# Altium schematic sheet Peripherals.SchDoc(Peripherals)
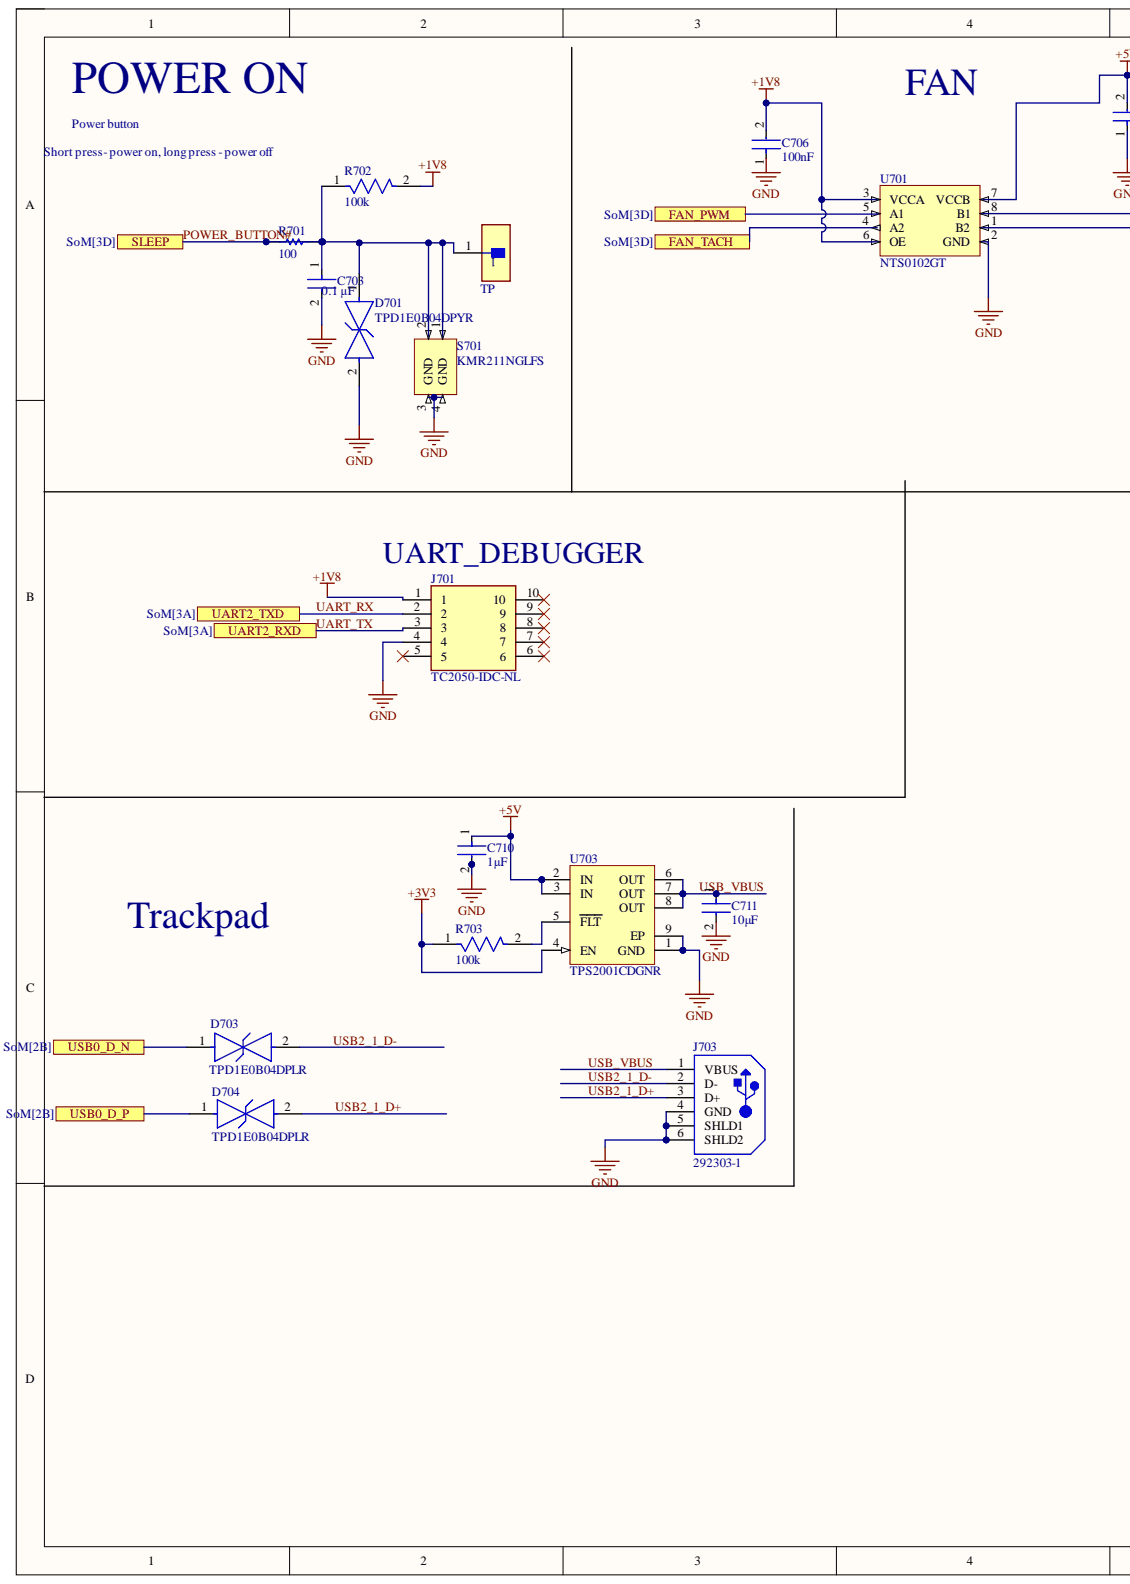
[diagram p0: Peripherals.SchDoc, left]
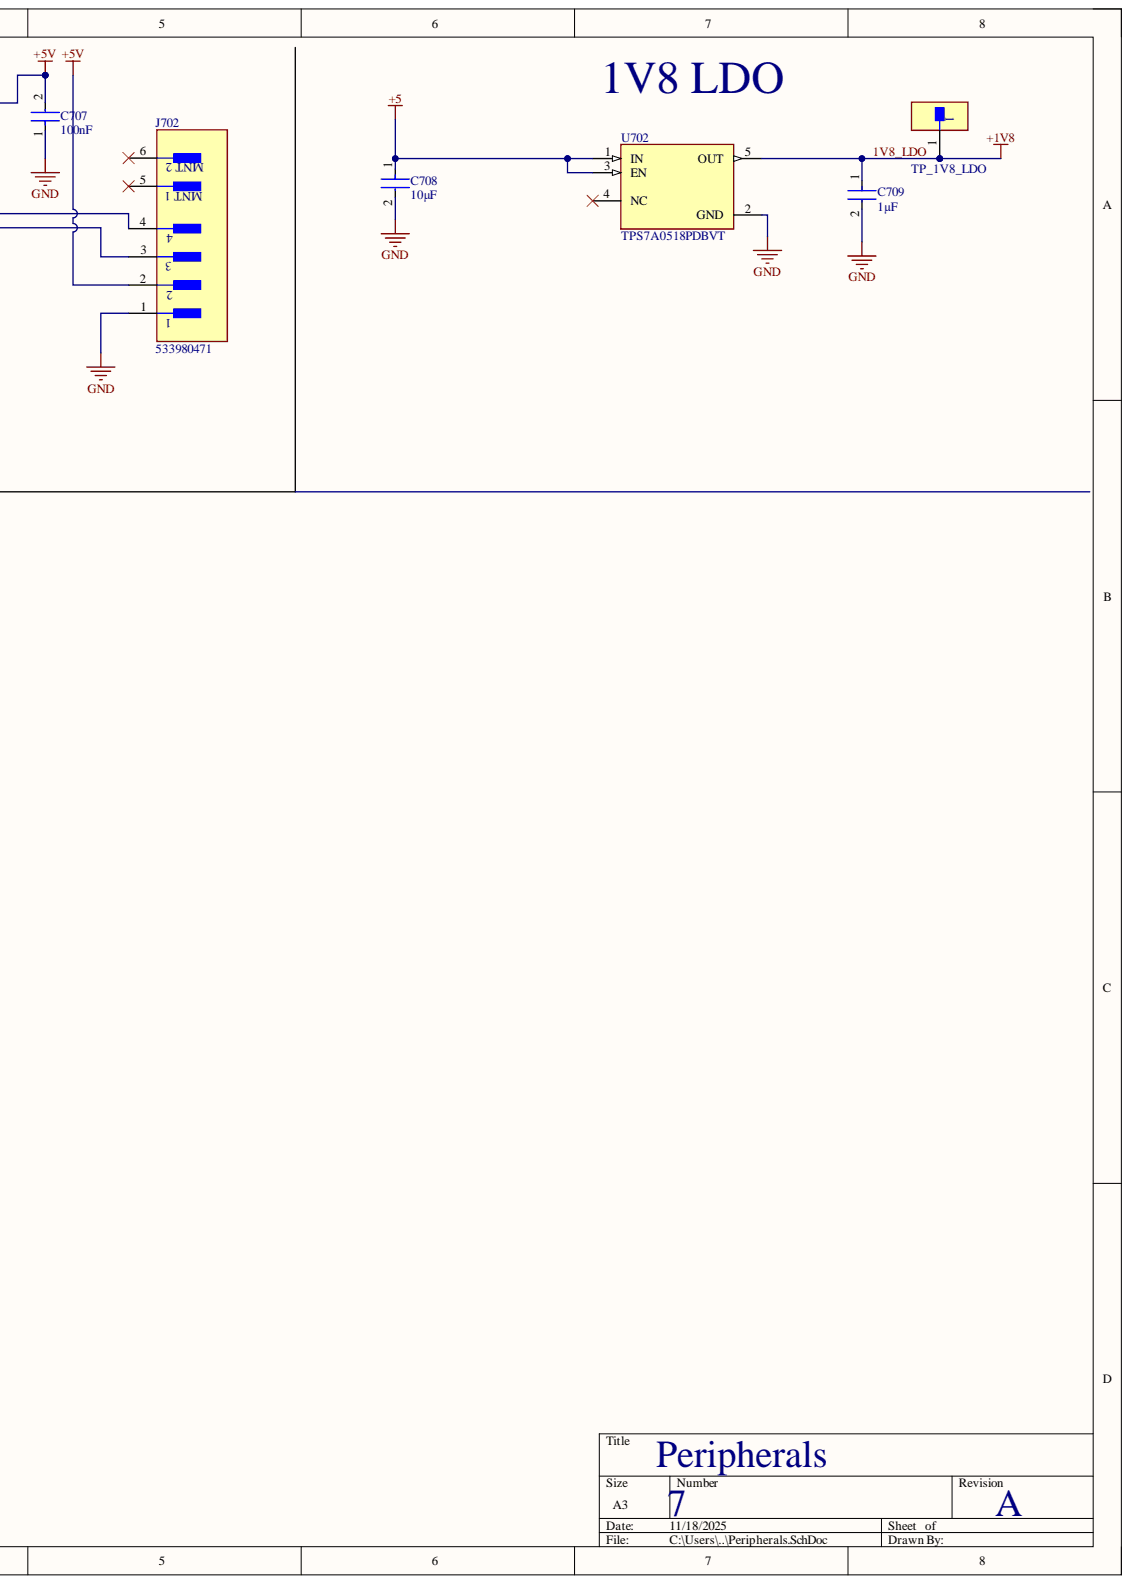
[diagram p1: Peripherals.SchDoc, right]

## Parts
C703  0.1 µF  [FP-GRM155-0_05-IPC_B]
C706  100nF  [FP-GRM155-0_05-IPC_B]
C707  100nF  [FP-GRM155-0_05-IPC_B]
C708  10μF  [FP-GRM31C-0_2-e0_3_0_8-MFG]
C709  1µF  [FP-GRM15-0_1-MFG]
C710  1µF  [FP-GRM15-0_1-MFG]
C711  10μF  [FP-GRM31C-0_2-e0_3_0_8-MFG]
D701  TPD1E0B04DPYR  [FP-DPY0002A-MFG]
D703  TPD1E0B04DPLR  [FP-DPL0002A-MFG]
D704  TPD1E0B04DPLR  [FP-DPL0002A-MFG]
J701  TC2050-IDC-NL  [TAG-CONNECT_TC2050-IDC-NL]
J702  533980471  [FP-533980471-MFG]
J703  292303-1  [TE-292303-1_V]
R701  100  [RESC1005X40X25ML05T10]
R702  100k  [FP-RC0402-0_4-IPC_A]
R703  100k  [FP-RC0402-0_4-IPC_A]
S701  KMR211NGLFS  [SW_KMR211NGLFS]
TP700  TP  [FP-S2751-46R-MFG]
TP701  TP_1V8_LDO  [FP-S2751-46R-MFG]
U701  NTS0102GT  [PSON50P100X195X50-8N]
U702  TPS7A0518PDBVT  [SOT95P280X145-5N]
U703  TPS2001CDGNR  [DGN0008D_L]

## Ports
FAN_PWM, FAN_TACH, SLEEP, UART2_RXD, UART2_TXD, USB0_D_N, USB0_D_P

## Nets
+3V3: R703.1 U703.4(EN)
+5: C708.1 U702.1(IN) U702.3(EN)
+5V: C707.2 C710.1 J702.2 U701.7(VCCB) U703.2(IN) U703.3(IN)
GND: C703.2 C706.1 C707.1 C708.2 C709.2 C710.2 C711.2 D701.2 J701.4 J702.1 J703.4(GND) J703.5(SHLD1) J703.6(SHLD2) S701.3(GND) S701.4(GND) U701.2(GND) U702.2(GND) U703.1(GND) U703.9(EP)
1V8_LDO: C706.2 C709.1 J701.1 R702.2 TP701.1 U701.3(VCCA) U701.6(OE) U702.5(OUT)
FAN_PWM: U701.5(A1) ; port FAN_PWM
FAN_TACH: U701.4(A2) ; port FAN_TACH
POWER_BUTTON#: C703.1 D701.1 R701.1 R701.2 R702.1 S701.1 S701.2 TP700.1 ; port SLEEP
UART_RX: J701.2 ; port UART2_TXD
UART_TX: J701.3 ; port UART2_RXD
USB0_D_N: D703.1 ; port USB0_D_N
USB0_D_P: D704.1 ; port USB0_D_P
USB2_1_D+: D704.2 J703.3(D+)
USB2_1_D-: D703.2 J703.2(D-)
USB_VBUS: C711.1 J703.1(VBUS) U703.6(OUT) U703.7(OUT) U703.8(OUT)
NetJ702_3: J702.3 U701.1(B2)
NetJ702_4: J702.4 U701.8(B1)
NetR703_2: R703.2 U703.5(FLT)

Not connected: J701 5 6 7 8 9 10; J702 5 6; U702 4(NC)

## Library data of the parts
C703 = CMP-06035-003750-1: Chip Multilayer Ceramic Capacitors for General Purpose, 0402, 0.10uF, X7R, 15%, 10%, 50V; Capacitance: 100nF; Case Code (Imperial): 0402; Case Code (Metric): 1005; Case/Package: 0402; Component Kind: Standard; Dielectric: X7R; Height: 0.5mm; Lead Free: Lead Free; Length: 0.039inch; Library Name: Altium Content Vault; Max Operating Temperature: 125°C; Min Operating Temperature: -55°C; Mount: Surface Mount; Package Quantity: 50000; Packaging: Tape and Reel; Resistor Type: Ceramic; Termination: SMD/SMT; Thickness: 0.022inch; Tolerance: 10%; Voltage Rating: 50V; Voltage Rating (DC): 50V; Weight: 0.000212oz; Width: 0.5mm
C706 C707 = CMP-2008-04639-2: Chip Multilayer Ceramic Capacitors for General Purpose, 0402, 0.10uF, X7R, 15%, 10%, 50V; Dissipation Factor: 0.025%; Capacitance: 100nF; Case Code (Imperial): 0402; Case Code (Metric): 1005; Case/Package: 0402; Component Kind: Standard; Depth: 0.5mm; Dielectric: X7R; Dielectric Material: Ceramic; Height: 0.5mm; Lead Free: Lead Free; Length: 1mm; Library Name: Altium Content Vault; Material: Ceramic; Max Operating Temperature: 125°C; Min Operating Temperature: -55°C; Mount: Surface Mount; Package Quantity: 10000; Packaging: Tape and Reel; REACH SVHC: No SVHC; Resistor Type: Ceramic; Termination: SMD/SMT; Thickness: 0.022inch; Tolerance: 10%; Voltage: 50V; Voltage Rating: 50V; Voltage Rating (DC): 50V; Weight: 0.000212oz; Width: 0.5mm
C708 C711 = CMP-06035-005515-1: Chip Multilayer Ceramic Capacitors for General Purpose, 1206, 10uF, X7R, 15%, 10%, 25V; Capacitance: 10uF; Case/Package: 1206; Component Kind: Standard; Dielectric: X7R; Lead Free: Lead Free; Length: 0.126inch; Library Name: Altium Content Vault; Max Operating Temperature: 125°C; Min Operating Temperature: -55°C; Mount: Surface Mount; Packaging: Tape and Reel; Thickness: 0.071inch; Tolerance: 10%; Voltage: 25V; Voltage Rating: 25V; Voltage Rating (DC): 25V; Width: 0.063inch
C709 C710 = CMP-2008-04556-2: CAP CER 1UF 10V X5R 0402; Manufacturer: Murata; Manufacturer Part Number: GRM155R61A105KE15D; Material: Ceramic; Temperature Coefficient: 15%; Tolerance: 10%; Automotive: No; Capacitance: 1uF; Category: Cap; Component Kind: Standard; ComponentLink2Description: Datasheet; ComponentLink2URL: https://search.murata.co.jp/Ceramy/image/img/A01X/G101/ENG/GRM155R61A105KE15-01A.pdf; Device Class L1: Passive Components; Device Class L2: Capacitors; Device Class L3: Ceramic Capacitors; Digikey Description: CAP CER 1UF 10V X5R 0402; Height: 0.55mm; Ipc Land Pattern Name: CAPC10050X50; Lead Free: Yes; Library Name: Altium Content Vault; Mouser Description: 0402 1 uF 10 V ±10% Tolerance X5R Surface Mount Multilayer Ceramic Capacitor; Octopart: https://octopart.com/grm155r61a105ke15d-murata-197442; Package: GRM15-0.1; RoHS: TRUE; Temperature: -55°C to +85°C; Temperature Characteristic: X5R; Temperature Range High: +85°C; Temperature Range Low: -55°C; Value: 1uF; Voltage Rating: 10V
D701 = CMP-04989-000020-1: TVS DIODE 3.6V 19V 2X1SON; Interface: USB; Min Breakdown Voltage: 6.7V; Number of Bidirectional Channels: 1; Peak Pulse Current: 1.7A; Peak Pulse Power: 15W; Power Line Protection: No; Case Code (Imperial): 0402; Case Code (Metric): 1006; Case/Package: 0402; Clamping Voltage: 19V; Component Kind: Standard; Library Name: Altium Content Vault; Max Operating Temperature: 125°C; Min Operating Temperature: -40°C; Mount: Surface Mount; Number of Channels: 1; Packaging: Cut Tape; Resistor Type: Zener; Reverse Standoff Voltage: 3.6V; RoHS Compliant: Yes
D703 D704 = CMP-04989-000017-1: TVS DIODE 3.6V 10.1V 2X2SON; Interface: USB; Number of Channels: 1; Component Kind: Standard; Lead Free: Lead Free; Library Name: Altium Content Vault; Max Operating Temperature: 125°C; Min Operating Temperature: -40°C; RoHS Compliant: Yes
J701 = CMP-002-00074-1: Adapter Cbl Plug Of Nails 10PIN; Component Kind: Standard; Library Name: Evan
J702 = CMP-04776-000105-1: CONN HEADER SMD 4POS 1.25MM; Manufacturer: Molex; Conn Gender: Male; Conn Orientation: Vertical; Current Rating: 1A; Number Of Contacts: 4; Standoff Height: 0.05mm; Voltage Rating: 125V; Ambient Temperature Range High: +105°C; Ambient Temperature Range Low: -40°C; Automotive: No; Category: Conn; Component Kind: Standard; ComponentLink2Description: Datasheet; ComponentLink2URL: https://www.molex.com/pdm_docs/ps/PS-51021-024-001.pdf; Contact Material: Tin; Device Class L1: Connectors; Device Class L2: Headers and Wire Housings; Device Class L3: unset; Digikey Description: CONN HEADER SMD 4POS 1.25MM; Footprint Url: https://www.molex.com/pdm_docs/sd/533980471_sd.pdf; Height: 5.1mm; Lead Free: Yes; Library Name: Altium Content Vault; Manufacturer Part Number: 533980471; Number Of Rows: 1; Octopart: https://octopart.com/53398-0471-molex-655296; Package: 0; Pitch: 1.25mm; RoHS: TRUE; Temperature: -40°C to +105°C; Temperature Range High: +105°C; Temperature Range Low: -40°C
J703 = CMP-2000-05404-1: 1 Port Right Angle Through Hole Mount Female Type A USB Connector, 4 Position, -55 to 85 degC, RoHS, Tube; Manufacturer: TE Connectivity; Connector Mounting: PCB; Connector Type: USBA; Contact Termination Type: Solder; Gender: Receptacle; No. of Contacts: 4; SVHC: NoSVHC(16-Jun-2014); Component Kind: Standard; Contact Material: Copper; Contact Plating: Gold; Datasheet URL: http://www.farnell.com/cad/1653030.pdf; Datasheet Version: Feb-12; Library Name: Altium Content Vault; Manufacturer URL: http://www.te.com/; Package Description: 4-Pin Through Hole Device, Body 14 x 13.13 mm, Pitch 2.5 mm; Package Reference: 292303-1
R701 = CMP-2002-07344-1: Manufacturer: Yageo / Phycomp; Part Number: RC0402FR-07100RL; Component Kind: Standard; Library Name: Altium Content Vault
R702 R703 = CMP-2002-07913-2: RES 100K OHM 5% 1/16W 0402; Max Power Dissipation: 63mW; Resistance: 100kR; Temperature Coefficient: 100ppm/°C; Voltage Rating: 50V; Case Code (Imperial): 0402; Case Code (Metric): 1005; Case/Package: 0402; Component Kind: Standard; Construction: Rectangular; Depth: 0.5mm; Features: Moisture Resistant; Height: 0.016inch; Lead Free: Lead Free; Length: 1mm; Library Name: Altium Content Vault; Max Operating Temperature: 155°C; Military Standard: Not; Min Operating Temperature: -55°C; Number of Terminations: 2; Package Quantity: 1; Packaging: Tape and Reel; Power Rating: 63mW; Resistance Tolerance: 5%; Resistor Type: Thick Film; RoHS Compliant: Yes; Series: RC; Size Code: 0402; Termination: SMD/SMT; Tolerance: 5%; Width: 0.02inch
S701 = CMP-016-00013-1: Switch Tactile N.O. SPST Round Button Gull Wing 0.05A 32VDC 1VA 200000Cycle 1.2N SMD T/R; Case/Package: SMD/SMT; Component Kind: Standard; Max Operating Temperature: 85°C; Min Operating Temperature: -40°C; Mounting Technology: Surface Mount; Library Name: Evan
TP700 TP701 = CMP-15817-000010-1: PC TEST POINT NATURAL; Body Material: Brass; Plating: Tin; Color: Natural; Component Kind: Standard; Library Name: Altium Content Vault; Material: Copper Alloy; Mount: Surface Mount; Packaging: Cut Tape
U701 = CMP-015-00011-1: Line Transceiver, PDSO8, 1 X 1.95 Mm, 0.50 Mm Height, Plastic, MO-252, SOT833-1, SON-8; Max Supply Voltage: 3.6V; Min Supply Voltage: 1.65V; Component Kind: Standard; Max Operating Temperature: 125°C; Min Operating Temperature: -40°C; Mounting Technology: Standard; Pins: 8; Library Name: Evan
U702 = CMP-019-00049-1: 200mA, ultra-low-IQ, high-accuracy, low-dropout voltage regulator with enable 5-SOT-23 -40 to 125; Output Voltage: 1.8V; Case/Package: SOT-23; Component Kind: Standard; Pins: 5; Library Name: Evan
U703 = CMP-0337-00296-2: Single Channel, Current-Limited USB Power Distribution Switch, 2.9 A Current Limit, -40 to 85 degC, 8-pin MSOP-PowerPAD (DGN), Green (RoHS & no Sb/Br); Manufacturer: Texas Instruments; Continuous Current(Max)(A): 2; Current Limit(A): 2.9; Discharge Function: TRUE; Enable: Active High; Number of Switches: 1; Rating: Catalog; rDS(on) per FET(Typ)(mOhms): 70; Vin(Max)(V): 5.5; Vin(Min)(V): 4.5; Code_JEDEC: MO-187-AA-T; Component Kind: Standard; ComponentLink1Description: Datasheet; ComponentLink1URL: http://www.ti.com/general/docs/lit/getliterature.tsp?genericPartNumber=TPS2001C&fileType=pdf; DatasheetVersion: SLVSAU6E; Eco-Plan: Green (RoHS & no Sb/Br); Library Name: Altium Content Vault; Mounting Technology: Surface Mount; PackageDescription: 8-Pin PowerPAD Plastic Small Outline Package 3 x 3 mm Body ,0.65 mm Pitch, Exposed Pad; PackageReference: DGN0008D; PackageVersion: revF, May-2011; PartNumber: TPS2001CDGNR; UL Listed: TRUE
